# Altium schematic sheet Netscale48HD_Sensorbar.SchDoc(Netscale48HD_Sensorbar)
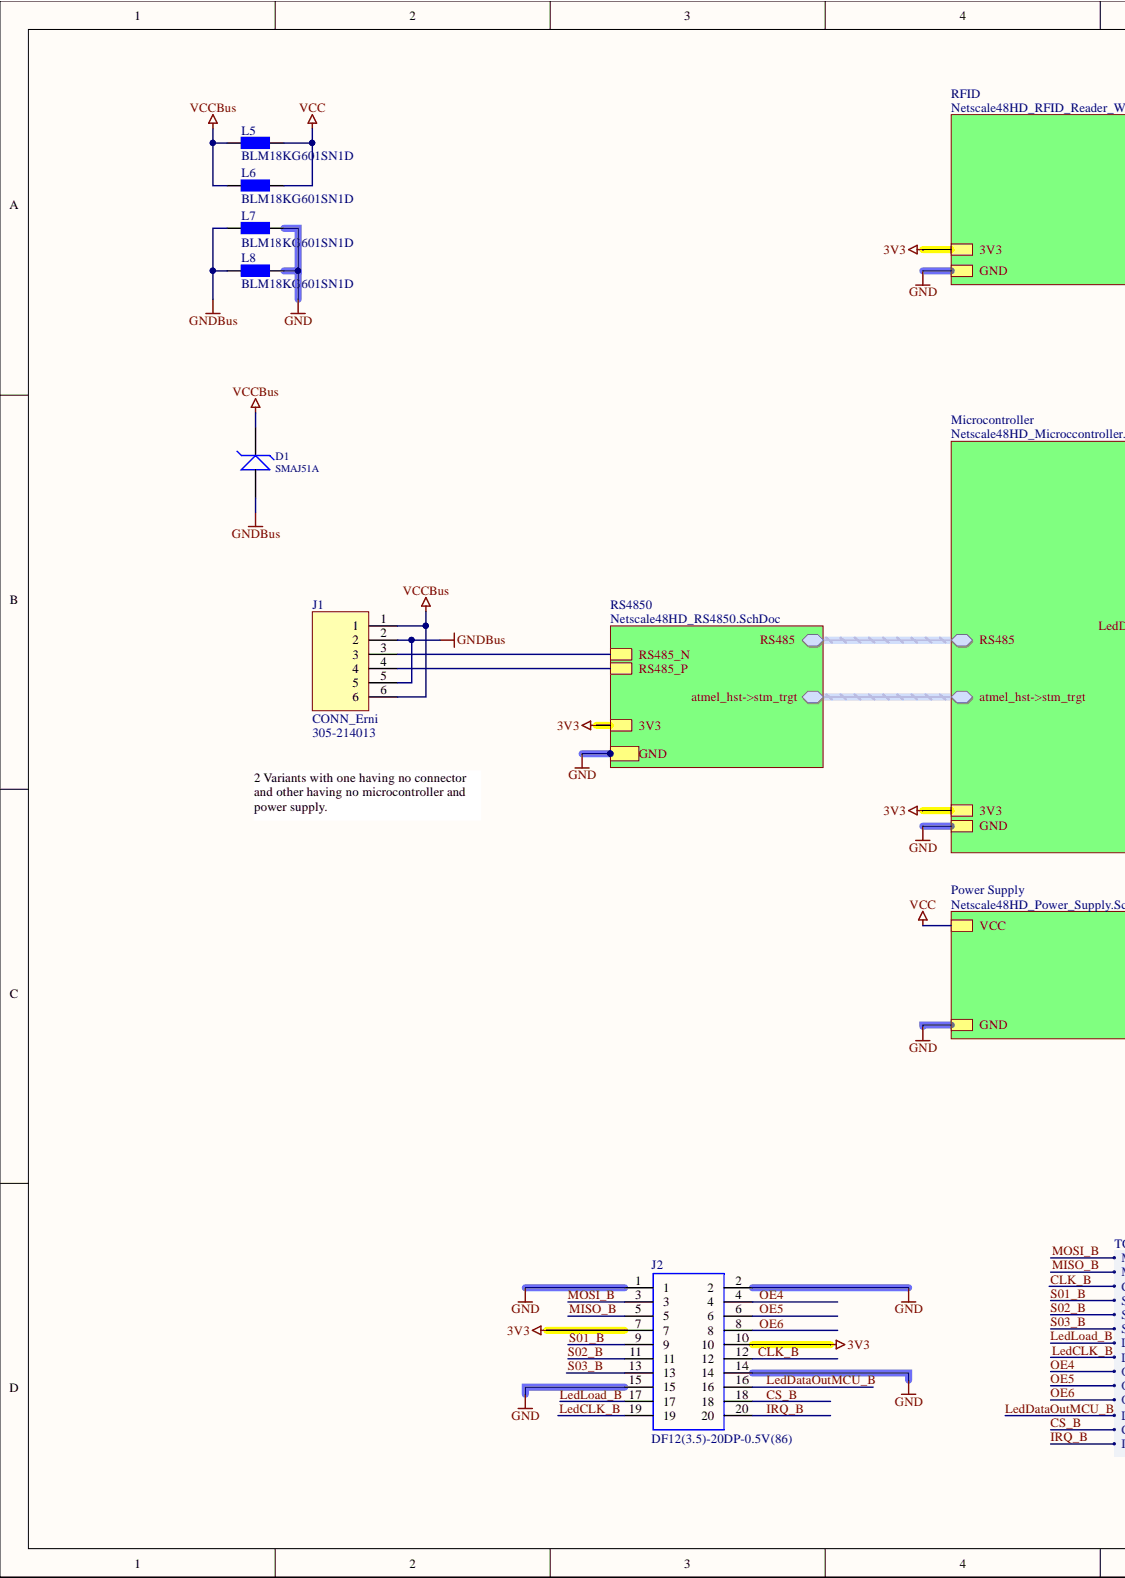
[diagram p0: Netscale48HD_Sensorbar.SchDoc, left]
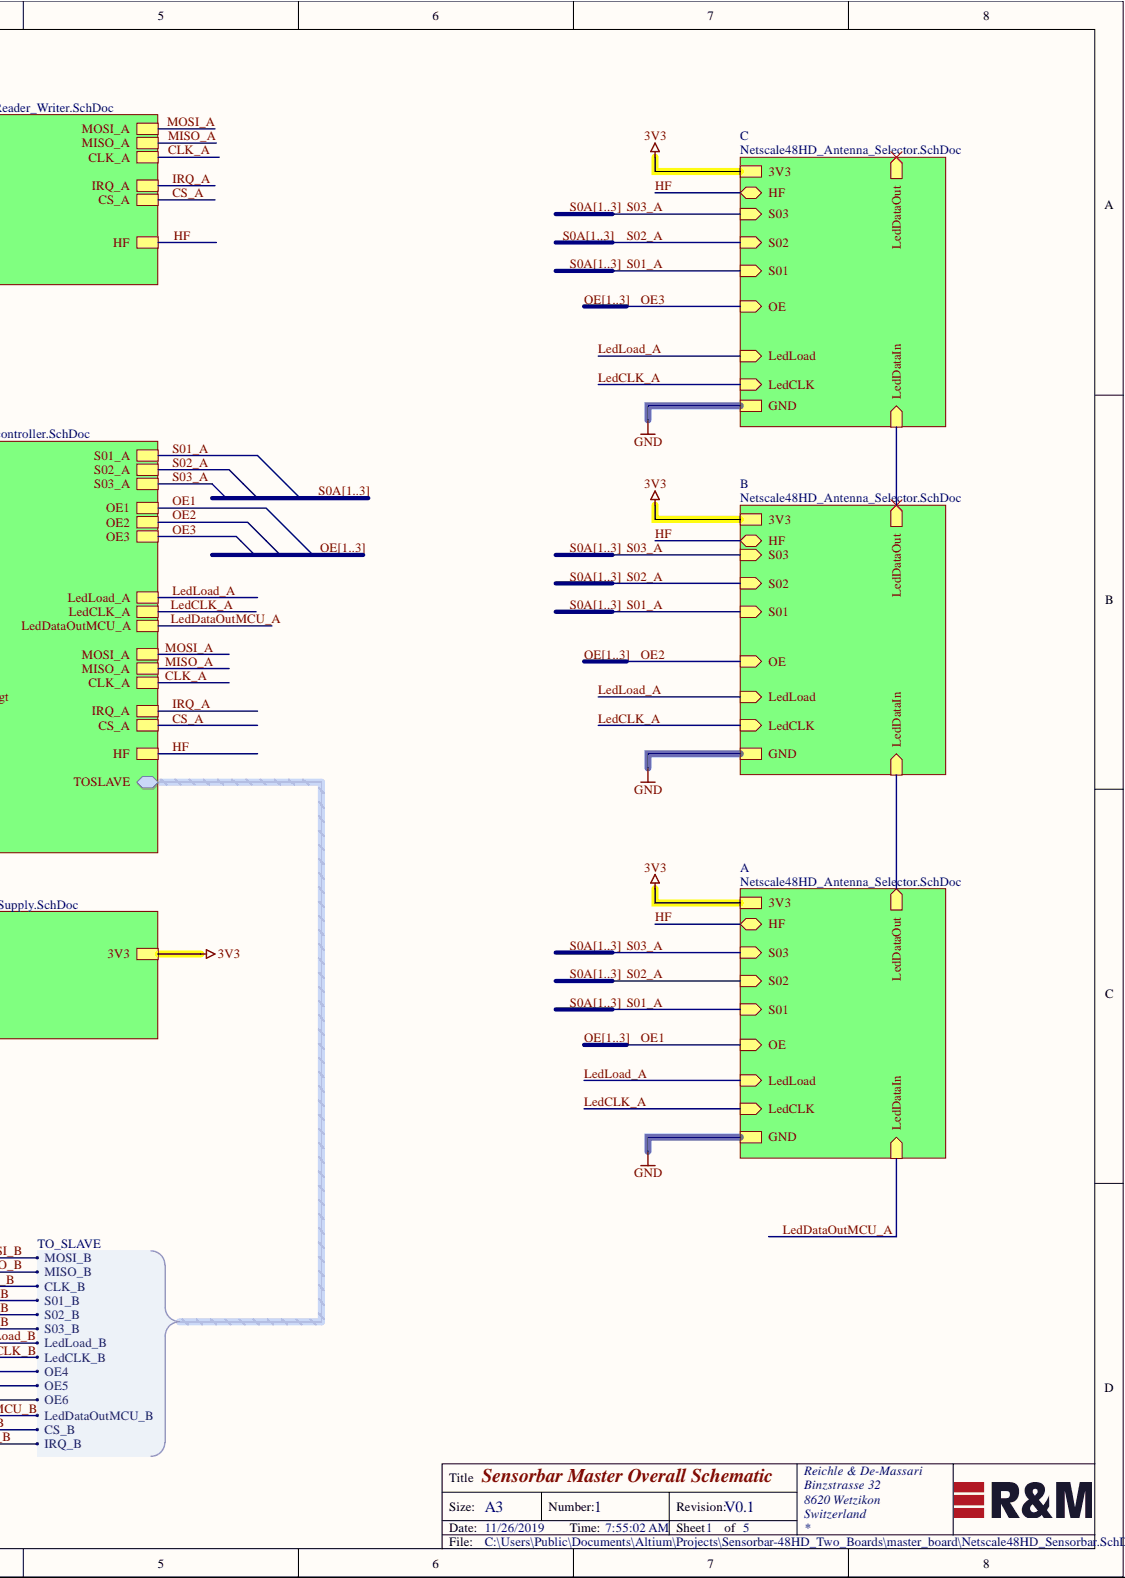
[diagram p1: Netscale48HD_Sensorbar.SchDoc, right]

## Parts
D1  SMAJ51A  [Bourns_DO-214AC (SMA) small_2_R]
J1  CONN_Erni  Supplier Part Number 1: 305-214013  [ERNI_214013 Randmontage_6_R]
J2  DF12(3.5)-20DP-0.5V(86)  [DF12(4.0)-20DP]
L5  BLM18KG601SN1D  [CAP-0603[1608] - RFL]
L6  BLM18KG601SN1D  [CAP-0603[1608] - RFL]
L7  BLM18KG601SN1D  [CAP-0603[1608] - RFL]
L8  BLM18KG601SN1D  [CAP-0603[1608] - RFL]

## Ports


## Nets
3V3: J2.7 J2.10
GNDBus: D1.2 J1.2 J1.5 L7.1 L8.1
VCC: L5.2 L6.2
VCCBus: D1.1 J1.1 J1.6 L5.1 L6.1
CLK_B: J2.12
CS_B: J2.18
GND: J2.1 J2.2 J2.14 J2.15 L7.2 L8.2 ; port 
IRQ_B: J2.20
LedCLK_B: J2.19
LedDataOutMCU_B: J2.16
LedLoad_B: J2.17
MISO_B: J2.5
MOSI_B: J2.3
OE4: J2.4  [also labelled OE]
OE5: J2.6  [also labelled OE]
OE6: J2.8  [also labelled OE]
S01_B: J2.9
S02_B: J2.11
S03_B: J2.13
NetJ1_3: J1.3
NetJ1_4: J1.4
atmel_hst->stm_trgt.ATMEL_EN:
atmel_hst->stm_trgt.ATMEL_NRST:
atmel_hst->stm_trgt.GPIO:
atmel_hst->stm_trgt.INT_REQUEST:
atmel_hst->stm_trgt.JTAG_TGT_NRST:
atmel_hst->stm_trgt.JTAG_TGT_TCK:
atmel_hst->stm_trgt.JTAG_TGT_TDI:
atmel_hst->stm_trgt.JTAG_TGT_TDO:
atmel_hst->stm_trgt.JTAG_TGT_TMS:
atmel_hst->stm_trgt.TWI_SCL_3.3V:
atmel_hst->stm_trgt.TWI_SDA_3.3V:
CLK_A:
CS_A:
HF:
IRQ_A:
LedCLK_A:
LedDataOut:
LedDataOutMCU_A:
LedLoad_A:
MISO_A:
MOSI_A:
OE1:  [also labelled OE]
OE2:  [also labelled OE]
OE3:  [also labelled OE]
RS485.RS485_BE:
RS485.RS485_CTS:
RS485.RS485_RTS:
RS485.RS485_RXD:
RS485.RS485_TXD:
S0A1:  [also labelled S0A]
S0A2:  [also labelled S0A]
S0A3:  [also labelled S0A]
S01_A:
S02_A:
S03_A:

## Library data of the parts
D1 = Diode_SMAJ51A: ESD Suppressors / TVS Diodes 51volts 5uA 4.9 Amps Uni-Dir; Manufacturer: Bourns; Replaceable: yes; value: SMAJ51A; Supplier Part Number 1: 652-SMAJ51A; Supplier 1: Mouser; ShortDescription: SMAJ51A; Manufacturer PartNr: SMAJ51A; Footprint/Package: DO-214AC-2 (SMA); Component Kind: Standard; Library Name: RDM_ALT_SCH.SchLib
J1 = CONN_Erni_6_214013: Headers & Wire Housings 6P 1.27MM SNGL ROW R/A MALE BLK; Manufacturer PartNr: 214013; Manufacturer: ERNI; Replaceable: no; Supplier 1: Mouser; Footprint/Package: 1.27mm; ComponentLink1URL: https://www.mouser.ch/ProductDetail/ERNI/214013?qs=sGAEpiMZZMvMXbh32ZmHAMhEPQG9jaj7Ou3J%2fuOb4IQ%3d; ComponentLink1Description: mouser; Component Kind: Standard; Library Name: RDM_ALT_SCH.SchLib
J2 = DF12(3.5)-20DP-0.5V(86): Connector,Board to Board,DF12 Hirose DF12 Series, 0.5mm Pitch 20 Way 2 Row Straight PCB Header, Solder Termination, 0.3A; Allied_Number: R1051072; Supplier 1 Part Number 1: H5230TR-ND; Supplier 1: Digi-Key; RS Price/Stock: http://uk.rs-online.com/web/p/products/6082064P; RS Part Number: 6082064P; Mouser Price/Stock: https://www.mouser.com/Search/Refine.aspx?Keyword=798-DF123520DP0.5V86; Mouser Part Number: 798-DF123520DP0.5V86; Manufacturer_Part_Number: DF12(3.5)-20DP-0.5V(86); Manufacturer_Name: Hirose; Datasheet Link: https://www.hirose.com/product/en/download_file/key_name/DF12/category/Catalog/doc_file_id/31693/?file_category_id=4&item_id=23&is_series=1.pdf; Component Kind: Standard; Allied Price/Stock: http://www.alliedelec.com/hirose-electric-df12-3-5-20dp-0-5v-86-/R1051072/; Library Name: RDM_ALT_SCH.SchLib
L5-L8 = Ferrite Beads: Chip Ferrite Bead; Manufacturer: Murata; ShortDescription: BLM18KG601SN1D; Manufacturer PartNr: BLM18KG601SN1D; Component Kind: Standard; Library Name: RDM_Altium_Library.IntLib
